# Altium schematic sheet main.SchDoc(main)
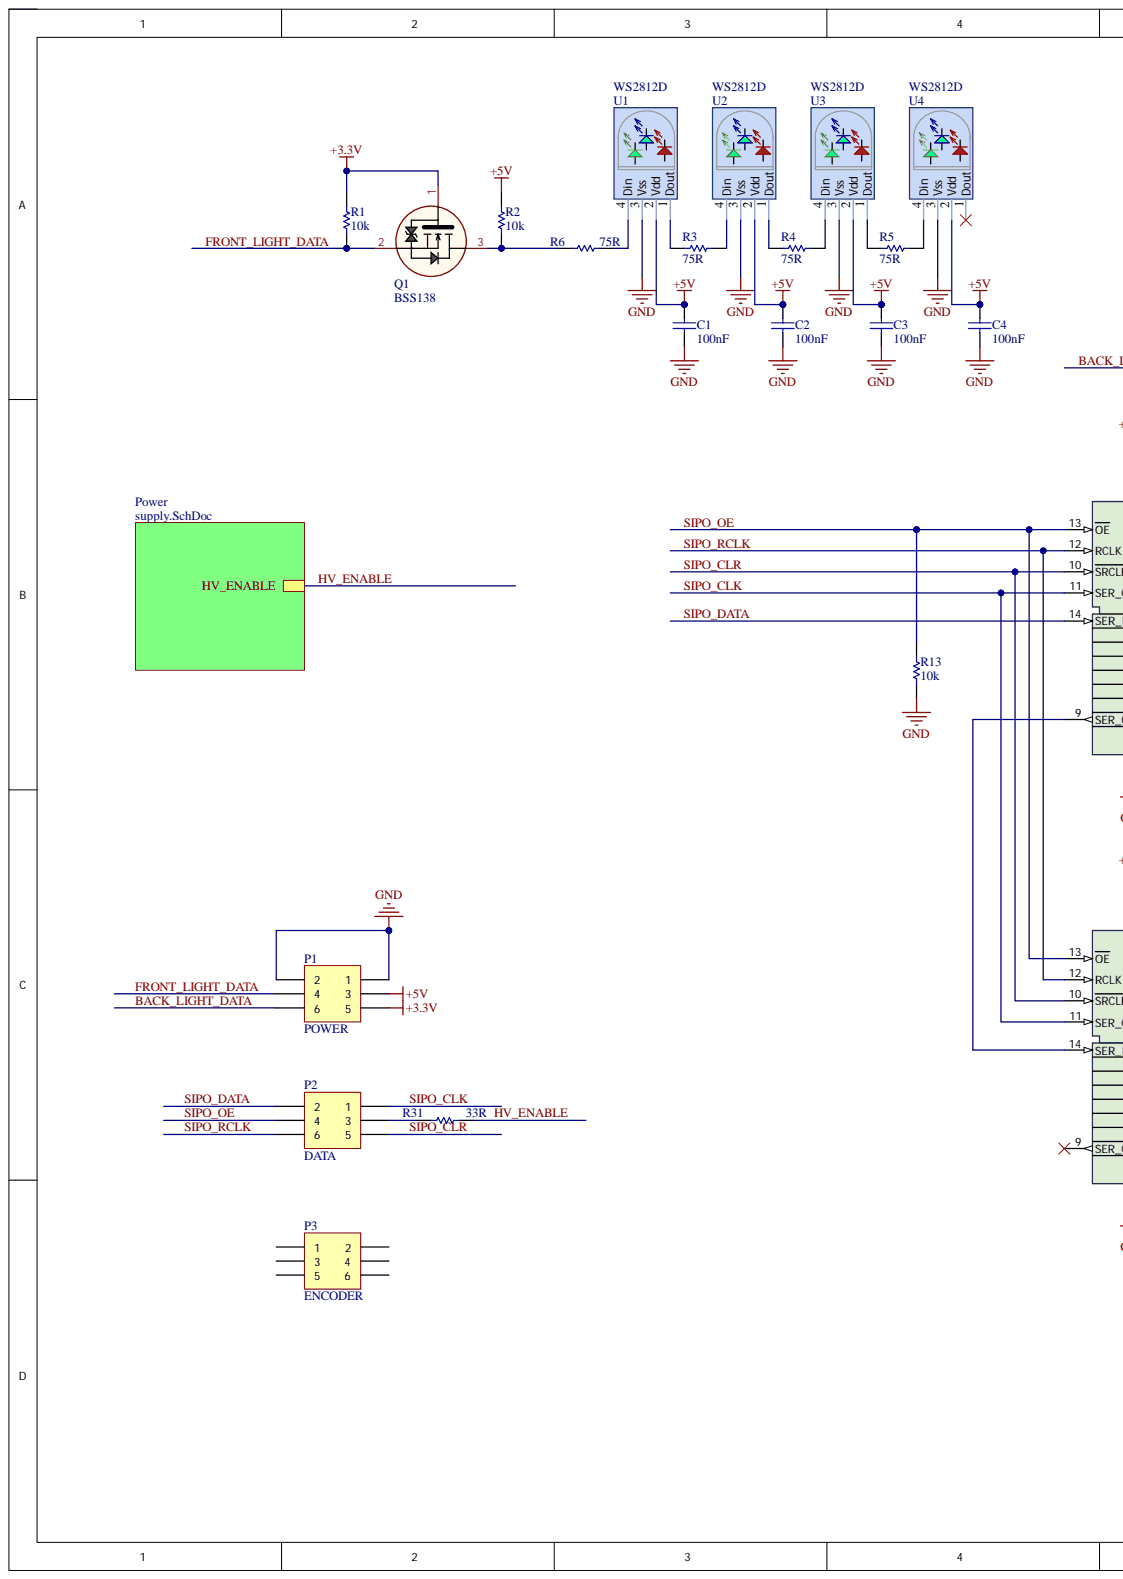
[diagram p0: main.SchDoc, left]
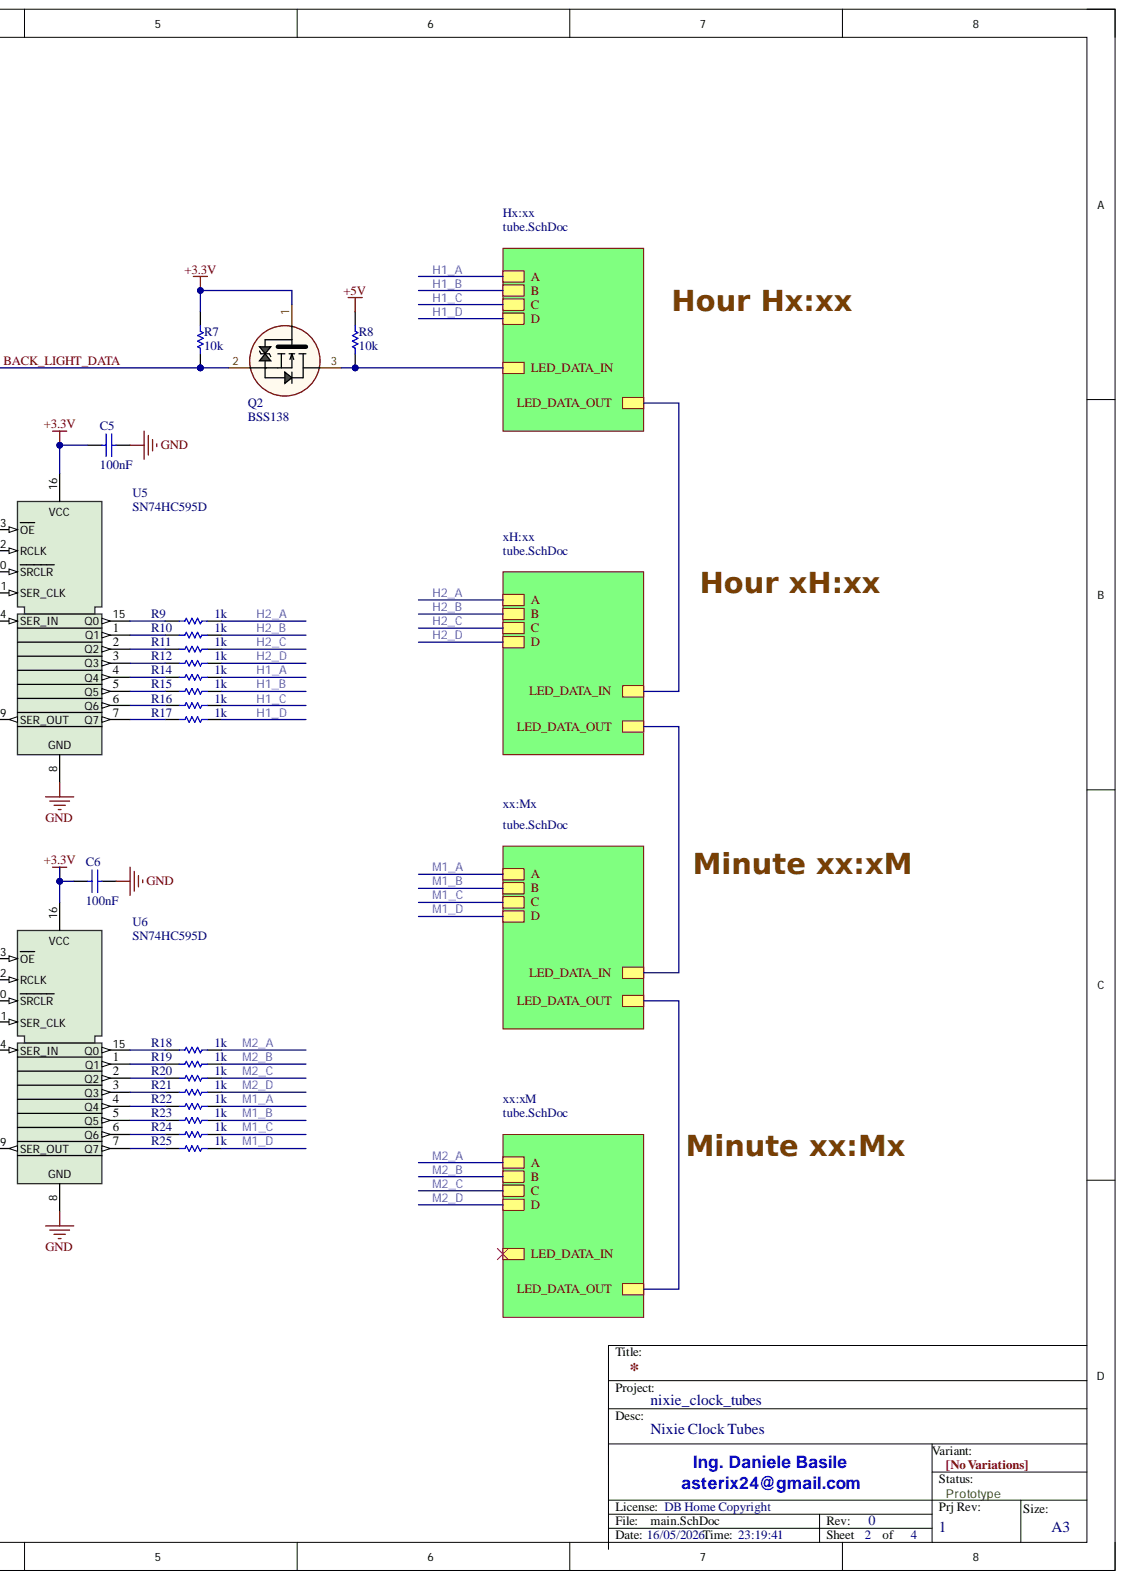
[diagram p1: main.SchDoc, right]

## Parts
C1  100nF  [0603_[1608]]
C2  100nF  [0603_[1608]]
C3  100nF  [0603_[1608]]
C4  100nF  [0603_[1608]]
C5  100nF  [0603_[1608]]
C6  100nF  [0603_[1608]]
P1  POWER  [HEADER_2X3_2.54MM_THD]
P2  DATA  [HEADER_2X3_2.54MM_THD]
P3  ENCODER  [HEADER_2X3_2.54MM_THD]
Q1  BSS138  [SOT-23]
Q2  BSS138  [SOT-23]
R1  10k  [0603_[1608]]
R2  10k  [0603_[1608]]
R3  75R  [0603_[1608]]
R4  75R  [0603_[1608]]
R5  75R  [0603_[1608]]
R6  75R  [0603_[1608]]
R7  10k  [0603_[1608]]
R8  10k  [0603_[1608]]
R9  1k  [0603_[1608]]
R10  1k  [0603_[1608]]
R11  1k  [0603_[1608]]
R12  1k  [0603_[1608]]
R13  10k  [0603_[1608]]
R14  1k  [0603_[1608]]
R15  1k  [0603_[1608]]
R16  1k  [0603_[1608]]
R17  1k  [0603_[1608]]
R18  1k  [0603_[1608]]
R19  1k  [0603_[1608]]
R20  1k  [0603_[1608]]
R21  1k  [0603_[1608]]
R22  1k  [0603_[1608]]
R23  1k  [0603_[1608]]
R24  1k  [0603_[1608]]
R25  1k  [0603_[1608]]
R31  33R  [0603_[1608]]
U1  WS2812D  [R5_RGB_LED_THD]
U2  WS2812D  [R5_RGB_LED_THD]
U3  WS2812D  [R5_RGB_LED_THD]
U4  WS2812D  [R5_RGB_LED_THD]
U5  SN74HC595D  [soic16]
U6  SN74HC595D  [soic16]

## Nets
+3.3V: C5.2 C6.2 P1.5 Q1.1 Q2.1 R1.1 R7.1 U5.16 U6.16
+5V: C1.1 C2.1 C3.1 C4.1 P1.3 R2.1 R8.1 U1.2(Vdd) U2.2(Vdd) U3.2(Vdd) U4.2(Vdd)
GND: C1.2 C2.2 C3.2 C4.2 C5.1 C6.1 P1.1 P1.2 R13.2 U1.3(Vss) U2.3(Vss) U3.3(Vss) U4.3(Vss) U5.8 U6.8
BACK_LIGHT_DATA: P1.6 Q2.2 R7.2
FRONT_LIGHT_DATA: P1.4 Q1.2 R1.2
H1_A: R14.1
H1_B: R15.1
H1_C: R16.1
H1_D: R17.1
H2_A: R9.1
H2_B: R10.1
H2_C: R11.1
H2_D: R12.1
HV_ENABLE: R31.1
M1_A: R22.1
M1_B: R23.1
M1_C: R24.1
M1_D: R25.1
M2_A: R18.1
M2_B: R19.1
M2_C: R20.1
M2_D: R21.1
SIPO_CLK: P2.1 U5.11(SER_CLK) U6.11(SER_CLK)
SIPO_CLR: P2.5 U5.10(SRCLR) U6.10(SRCLR)
SIPO_DATA: P2.2 U5.14(SER_IN)
SIPO_OE: P2.4 R13.1 U5.13(OE) U6.13(OE)
SIPO_RCLK: P2.6 U5.12(RCLK) U6.12(RCLK)
NetP2_3: P2.3 R31.2
NetP3_1: P3.1
NetP3_2: P3.2
NetP3_3: P3.3
NetP3_4: P3.4
NetP3_5: P3.5
NetP3_6: P3.6
NetQ1_3: Q1.3 R2.2 R6.2
NetQ2_3: Q2.3 R8.2
NetR3_1: R3.1 U1.1(Dout)
NetR3_2: R3.2 U2.4(Din)
NetR4_1: R4.1 U2.1(Dout)
NetR4_2: R4.2 U3.4(Din)
NetR5_1: R5.1 U3.1(Dout)
NetR5_2: R5.2 U4.4(Din)
NetR6_1: R6.1 U1.4(Din)
NetR9_2: R9.2 U5.15(Q0)
NetR10_2: R10.2 U5.1(Q1)
NetR11_2: R11.2 U5.2(Q2)
NetR12_2: R12.2 U5.3(Q3)
NetR14_2: R14.2 U5.4(Q4)
NetR15_2: R15.2 U5.5(Q5)
NetR16_2: R16.2 U5.6(Q6)
NetR17_2: R17.2 U5.7(Q7)
NetR18_2: R18.2 U6.15(Q0)
NetR19_2: R19.2 U6.1(Q1)
NetR20_2: R20.2 U6.2(Q2)
NetR21_2: R21.2 U6.3(Q3)
NetR22_2: R22.2 U6.4(Q4)
NetR23_2: R23.2 U6.5(Q5)
NetR24_2: R24.2 U6.6(Q6)
NetR25_2: R25.2 U6.7(Q7)
NetU4_1: U4.1(Dout)
NetU5_9: U5.9(SER_OUT) U6.14(SER_IN)
NetU6_9: U6.9(SER_OUT)
LED_DATA_IN:
LED_DATA_OUT:

## Library data of the parts
C1-C6 = Cap: Capacitor; Component Kind: Standard; ComponentLink1Description: New link; Signal Integrity: Cap; Simulation: CAP; Value: 100nF; Library Name: develer_generic.schlib
P1-P3 = Header 3X2: Header, 3-Pin, Dual row; Component Kind: Standard; LatestRevisionDate: 17-Jul-2002; LatestRevisionNote: Re-released for DXP Platform.; Library Name: Miscellaneous Connectors.IntLib; Signal Integrity: Connector; Publisher: Altium Limited
Q1 Q2 = BSS138: N-MOSFET 50V 0,22A; Component Kind: Standard; MountTechnology: SMT; Revision: 0.1; Library Name: develer_components.SchLib; Publisher: Develer srl
R1-R25 R31 = Res: Resistor; Component Kind: Standard; MountTechnology: SMT; Revision: 0.1; Signal Integrity: Res; Simulation: RESISTOR; Library Name: develer_generic.schlib; Publisher: Develer srl
U1-U4 = WS2812D: Adressable Led RGB 1wire, THD; CODE LCSC: C190565; Component Kind: Standard; MountTechnology: SMT; Revision: 0.1; Library Name: develer_components.SchLib; Publisher: Develer srl
U5 U6 = SN74HC595D: 8-Bit Shift Registers With 3-State Output Registers; Component Kind: Standard; MountTechnology: SMT; Revision: 0.1; Supplier 1: Digi-Key; Supplier 2: Newark; Supplier 3: Newark; Supplier 4: Avnet; Supplier Part Number 1: 497-2344-5-ND; Supplier Part Number 2: 26AC5117; Supplier Part Number 3: 89K1130; Supplier Part Number 4: ULN2003A; Library Name: develer_components.SchLib; Publisher: Develer srl
